# Altium schematic sheet RoBeast_uC.SchDoc(RoBeast_uC)
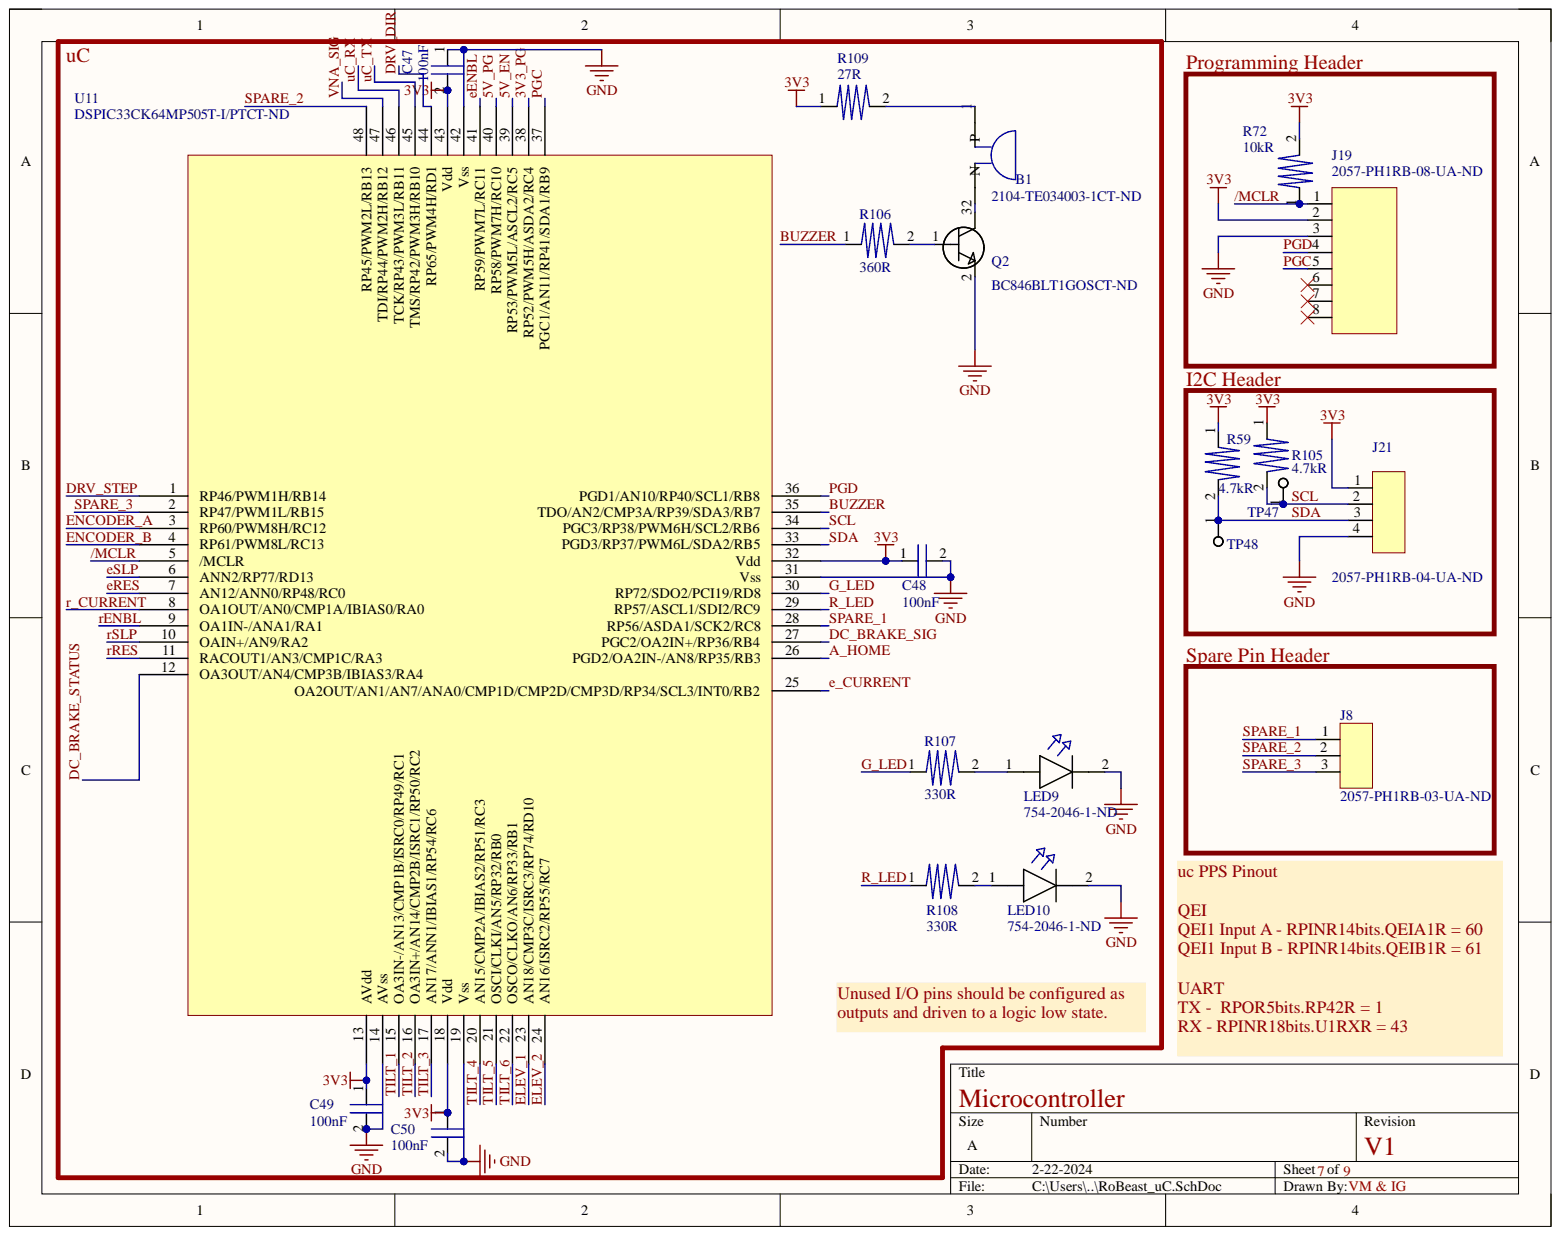
[diagram p0: RoBeast_uC.SchDoc]

## Parts
B1  Supplier Part Number 1: 2104-TE034003-1CT-ND  [TE034003-1]  (hidden comment: Buzzer)
C47  100nF  [0805]
C48  100nF  [0805]
C49  100nF  [0805]
C50  100nF  [0805]
J8  Supplier Part Number: 2057-PH1RB-03-UA-ND  [PH1RB-03-UA]  (hidden comment: 3 Pin Connector)
J19  Supplier Part Number 1: 2057-PH1RB-08-UA-ND  [PH1RB-08-UA]  (hidden comment: 8 Pin Connector)
J21  Supplier Part Number: 2057-PH1RB-04-UA-ND  [PH1RB-04-UA]  (hidden comment: 4 Pin Connector)
LED9  Supplier Part Number 1: 754-2046-1-ND  [0805_LED]  (hidden comment: Blue LED)
LED10  Supplier Part Number 1: 754-2046-1-ND  [0805_LED]  (hidden comment: Blue LED)
Q2  Supplier Part Number 1: BC846BLT1GOSCT-ND  [BC846BLT1G]  (hidden comment: NPN BJT)
R59  4.7kR  [0805]
R72  10kR  [0805]
R105  4.7kR  [0805]
R106  360R  [0805]
R107  330R  [0805]
R108  330R  [0805]
R109  27R  [0805]
TP47  Test Point  [RCWCTE]  (value not drawn)
TP48  Test Point  [RCWCTE]  (value not drawn)
U11  uC  Supplier Part Number: DSPIC33CK64MP505T-I/PTCT-ND  [DSPIC33CK64MP505T-I/PT]

## Nets
3V3: C47.2 C48.1 C49.1 C50.1 J19.2 J21.1 R59.1 R72.2 R105.1 R109.1 U11.13(AVdd) U11.18(Vdd) U11.32(Vdd) U11.43(Vdd)
GND: C47.1 C48.2 C49.2 C50.2 J19.3 J21.4 LED9.2 LED10.2 Q2.2 U11.14(AVss) U11.19(Vss) U11.31(Vss) U11.42(Vss)
3V3_PG: U11.38(RP52/PWM5H/ASDA2/RC4)
5V_EN: U11.39(RP53/PWM5L/ASCL2/RC5)
5V_PG: U11.40(RP58/PWM7H/RC10)
/MCLR: J19.1 R72.1 U11.5(/MCLR)
A_HOME: U11.26(PGD2/OA2IN-/AN8/RP35/RB3)
BUZZER: R106.1 U11.35(TDO/AN2/CMP3A/RP39/SDA3/RB7)
DC_BRAKE_SIG: U11.27(PGC2/OA2IN+/RP36/RB4)
DC_BRAKE_STATUS: U11.12(OA3OUT/AN4/CMP3B/IBIAS3/RA4)
DRV_DIR: U11.44(RP65/PWM4H/RD1)
DRV_STEP: U11.1(RP46/PWM1H/RB14)
e_CURRENT: U11.25(OA2OUT/AN1/AN7/ANA0/CMP1D/CMP2D/CMP3D/RP34/SCL3/INT0/RB2)
eENBL: U11.41(RP59/PWM7L/RC11)
ELEV_1: U11.23(AN18/CMP3C/ISRC3/RP74/RD10)
ELEV_2: U11.24(AN16/ISRC2/RP55/RC7)
ENCODER_A: U11.3(RP60/PWM8H/RC12)
ENCODER_B: U11.4(RP61/PWM8L/RC13)
eRES: U11.7(AN12/ANN0/RP48/RC0)
eSLP: U11.6(ANN2/RP77/RD13)
G_LED: R107.1 U11.30(RP72/SDO2/PCI19/RD8)
PGC: J19.5 U11.37(PGC1/AN11/RP41/SDA1/RB9)
PGD: J19.4 U11.36(PGD1/AN10/RP40/SCL1/RB8)
r_CURRENT: U11.8(OA1OUT/AN0/CMP1A/IBIAS0/RA0)
R_LED: R108.1 U11.29(RP57/ASCL1/SDI2/RC9)
rENBL: U11.9(OA1IN-/ANA1/RA1)
rRES: U11.11(RACOUT1/AN3/CMP1C/RA3)
rSLP: U11.10(OAIN+/AN9/RA2)
SCL: J21.2 R105.2 TP47.1 U11.34(PGC3/RP38/PWM6H/SCL2/RB6)
SDA: J21.3 R59.2 TP48.1 U11.33(PGD3/RP37/PWM6L/SDA2/RB5)
SPARE_1: J8.1 U11.28(RP56/ASDA1/SCK2/RC8)
SPARE_2: J8.2 U11.48(RP45/PWM2L/RB13)
SPARE_3: J8.3 U11.2(RP47/PWM1L/RB15)
TILT_1: U11.15(OA3IN-/AN13/CMP1B/ISRC0/RP49/RC1)
TILT_2: U11.16(OA3IN+/AN14/CMP2B/ISRC1/RP50/RC2)
TILT_3: U11.17(AN17/ANN1/IBIAS1/RP54/RC6)
TILT_4: U11.20(AN15/CMP2A/IBIAS2/RP51/RC3)
TILT_5: U11.21(OSCI/CLKI/AN5/RP32/RB0)
TILT_6: U11.22(OSCO/CLKO/AN6/RP33/RB1)
uC_RX: U11.46(TCK/RP43/PWM3L/RB11)
uC_TX: U11.45(TMS/RP42/PWM3H/RB10)
VNA_SIG: U11.47(TDI/RP44/PWM2H/RB12)
NetB1_1: B1.1 R109.2
NetB1_2: B1.2 Q2.3
NetLED9_1: LED9.1 R107.2
NetLED10_1: LED10.1 R108.2
NetQ2_1: Q2.1 R106.2

Not connected: J19 6 7 8

## Library data of the parts
B1 = TE034003-1: Electro-mechanical Transducer; Manufacturer: DB Unlimited; Category: Audio Products; Component Kind: Standard; Manufacturer Part Number: TE034003-1; Pricing 1: 1=2.3, 10=1.911, 25=1.7652, 50=1.659, 100=1.5568, 250=1.44984, 500=1.33496, 1000=1.29987 (USD); RoHS: No; Stock 1: 5468; Supplier 1: Digikey; Library Name: RoBeast_Schematic_Library.SchLib
C47-C50 = C0805C104J5RAC7800: Ceramic Capacitor, Multilayer, Ceramic, 50V, 5% +Tol, 5% -Tol, X7R, 15% TC, 0.1uF, Surface Mount, 0805; Manufacturer: KEMET; Manufacturer Part Number: C0805C104J5RACTU; Multilayer: Yes; Capacitance: 100nF; Capacitor Type: Ceramic; Category: Ceramic Capacitors; Component Kind: Standard; ComponentLink1Description: http://capacitoredge.kemet.com:80/capedge2/intellispec.jsp?pn=C0805C104J5RACTU&displayPN=C0805C104J5RACTU&src-supplier=IHS+Markit; ComponentLink1URL: http://capacitoredge.kemet.com:80/capedge2/intellispec.jsp?pn=C0805C104J5RACTU&displayPN=C0805C104J5RACTU&src-supplier=IHS+Markit; ComponentLink2Description: https://datasheet.ciiva.com/7842/1732721-7842179.pdf?src-supplier=Element14; ComponentLink2URL: https://datasheet.ciiva.com/7842/1732721-7842179.pdf?src-supplier=Element14; ComponentLink3Description: https://datasheet.ciiva.com/28682/1851736-28682223.pdf?src-supplier=Element14; ComponentLink3URL: https://datasheet.ciiva.com/28682/1851736-28682223.pdf?src-supplier=Element14; ComponentLink4Description: https://datasheet.ciiva.com/7258/1828800-7258413.pdf?src-supplier=Newark; ComponentLink4URL: https://datasheet.ciiva.com/7258/1828800-7258413.pdf?src-supplier=Newark; ComponentLink5Description: https://datasheet.ciiva.com/14589/70095383-14589015.pdf?src-supplier=Allied+Electronics; ComponentLink5URL: https://datasheet.ciiva.com/14589/70095383-14589015.pdf?src-supplier=Allied+Electronics; ComponentLink6Description: https://datasheet.ciiva.com/5417/kem-c1002-x7r-smd-5417352.pdf?src-supplier=Mouser; ComponentLink6URL: https://datasheet.ciiva.com/5417/kem-c1002-x7r-smd-5417352.pdf?src-supplier=Mouser; ComponentLink7Description: https://datasheet.ciiva.com/39122/docfinderpartnumberc0402c102k5ractu-39122924.pdf?src-supplier=Onlinecomponents.com; ComponentLink7URL: https://datasheet.ciiva.com/39122/docfinderpartnumberc0402c102k5ractu-39122924.pdf?src-supplier=Onlinecomponents.com; Dielectric Material: Ceramic; JESD-609 Code: e3; Max Operating Temperature: 125°C; Min Operating Temperature: -55°C; Mount: Surface Mount; Negative Tolerance: 5%; Number of Pins: 2; Package Shape: Rectangular; Packaging: Tape and Reel; Positive Tolerance: 5%; Pricing 1: 1=0.18, 10=0.122, 50=0.0732, 100=0.0576, 500=0.04252, 1000=0.03519 (USD); Rated DC Voltage (URdc): 50V; Reach SVHC Compliant: Yes; RoHS: Yes; RoHS Compliant: Yes; Size Code: 0805; Stock 1: 605061; Supplier 1: Digikey; Supplier Part Number 1: 399-C0805C104J5RAC7800CT-ND; Temperature Characteristics Code: X7R; Terminal Finish: Nickel; Termination: Wraparound; Library Name: RoBeast_Schematic_Library.SchLib
J8 = PH1RB-03-UA: Conn Unshrouded Header HDR 3 POS 2.54mm Solder RA Side Entry Thru-Hole; Manufacturer: Adam Equipment; Category: Connectors; Component Kind: Standard; ComponentLink1Description: http://www.adam-tech.com/downloader.php?p=PH1RB-XX-UA.pdf&src-supplier=Digikey; ComponentLink1URL: http://www.adam-tech.com/downloader.php?p=PH1RB-XX-UA.pdf&src-supplier=Digikey; Manufacturer Part Number: PH1RB-03-UA; Pricing: 1=0.12, 10=0.097, 100=0.0718, 500=0.05938, 1000=0.05196, 5000=0.04454, 10000=0.0433 (USD); RoHS: No; Stock: 27919; Supplier: Digikey; Library Name: RoBeast_Schematic_Library.SchLib
J19 = PH1RB-08-UA: Conn Unshrouded Header HDR 8 POS 2.54mm Solder RA Thru-Hole; Manufacturer: Adam Equipment; Category: Connectors; Component Kind: Standard; Manufacturer Part Number: PH1RB-08-UA; Pricing 1: 1=0.23, 10=0.196, 100=0.1505, 500=0.12738, 1000=0.10423, 5000=0.09265, 10000=0.08396 (USD); RoHS: No; Stock 1: 10117; Supplier 1: Digikey; Library Name: RoBeast_Schematic_Library.SchLib
J21 = PH1RB-04-UA: Conn Unshrouded Header HDR 4 POS 2.54mm Solder RA Thru-Hole; Manufacturer: Adam Equipment; Category: Connectors; Component Kind: Standard; ComponentLink1Description: http://www.adam-tech.com/downloader.php?p=PH1RB-XX-UA.pdf&src-supplier=Digikey; ComponentLink1URL: http://www.adam-tech.com/downloader.php?p=PH1RB-XX-UA.pdf&src-supplier=Digikey; Manufacturer Part Number: PH1RB-04-UA; Pricing: 1=0.12, 10=0.094, 100=0.0697, 500=0.0577, 1000=0.05048, 5000=0.04327, 10000=0.04207 (USD); RoHS: No; Stock: 13315; Supplier: Digikey; Library Name: RoBeast_Schematic_Library.SchLib
LED9 LED10 = APTD2012LQBC/D: Single Color LED; Manufacturer: Kingbright; Category: LEDs; Component Kind: Standard; ComponentLink1Description: https://datasheet.ciiva.com:443/pdfs/VipMasterIC/IC/KBEC/KBEC-S-A0008946974/KBEC-S-A0008947044-1.pdf?src-supplier=IHS+Markit; ComponentLink1URL: https://datasheet.ciiva.com:443/pdfs/VipMasterIC/IC/KBEC/KBEC-S-A0008946974/KBEC-S-A0008947044-1.pdf?src-supplier=IHS+Markit; ComponentLink2Description: http://www.kingbrightusa.com/images/catalog/SPEC/APTD2012LQBC-D.pdf?src-supplier=Digikey; ComponentLink2URL: http://www.kingbrightusa.com/images/catalog/SPEC/APTD2012LQBC-D.pdf?src-supplier=Digikey; Manufacturer Part Number: APTD2012LQBC/D; Pricing 1: 1=0.54, 10=0.358, 100=0.2367, 1000=0.18275 (USD); RoHS: Yes; RoHS Compliant: Yes; Stock 1: 20866; Supplier 1: Digikey; Library Name: RoBeast_Schematic_Library.SchLib
Q2 = BC846BLT1G: Small Signal Bipolar Transistor, 0.1A I(C), 65V V(BR)CEO, 1-Element, NPN, Silicon, TO-236; Manufacturer: ON Semiconductor; DC Current Gain-Min (hFE): 200; Max Collector Current: 100mA; Number of Elements: 1; Package Body Material: Plastic; Power Dissipation-Max (Abs): 225mW; Qualification Status: Not Qualified; Time @ Peak Reflow Temperature-Max (s): 40s; Transistor Application: SWITCHING; Transition Frequency-Nom (fT): 100MHz; Category: BJTs; Collector-emitter Voltage-Max: 65V; Component Kind: Standard; ComponentLink1Description: https://datasheet.ciiva.com:443/pdfs/VipMasterIC/IC/ONSM/ONSM-S-A0009451914/ONSM-S-A0009451914-1.pdf?src-supplier=IHS+Markit; ComponentLink1URL: https://datasheet.ciiva.com:443/pdfs/VipMasterIC/IC/ONSM/ONSM-S-A0009451914/ONSM-S-A0009451914-1.pdf?src-supplier=IHS+Markit; ComponentLink2Description: https://datasheet.ciiva.com/15138/getdatasheetpartid-38790-15138644.pdf?src-supplier=Verical; ComponentLink2URL: https://datasheet.ciiva.com/15138/getdatasheetpartid-38790-15138644.pdf?src-supplier=Verical; ComponentLink3Description: https://datasheet.ciiva.com/27056/2010421-27056146.pdf?src-supplier=Jameco; ComponentLink3URL: https://datasheet.ciiva.com/27056/2010421-27056146.pdf?src-supplier=Jameco; ComponentLink4Description: https://datasheet.ciiva.com/7972/bc846alt1-d-7972189.pdf?src-supplier=Element14; ComponentLink4URL: https://datasheet.ciiva.com/7972/bc846alt1-d-7972189.pdf?src-supplier=Element14; JEDEC-95 Code: TO-236; JESD-30 Code: R-PDSO-G3; JESD-609 Code: e3; Manufacturer Part Number: BC846BLT1G; Max Operating Temperature: 150°C; Moisture Sensitivity Level: 1; Number of Pins: 3; Package Shape: Rectangular; Package Style: SMALL OUTLINEMeter; Peak Reflow Temperature (Cel): 260°C; Polarity/Channel Type: NPN; Pricing 1: 1=0.14, 10=0.097, 100=0.0522, 500=0.04102, 1000=0.02848 (USD); Reach SVHC Compliant: Yes; RoHS: Yes; RoHS Compliant: Yes; Stock 1: 1618453; Supplier 1: Digikey; Surface Mount: Yes; Terminal Finish: Tin; Terminal Form: Gull Wing; Terminal Position: DUAL; Transistor Element Material: SILICON; Library Name: RoBeast_Schematic_Library.SchLib
R59 R105 = RCC08054K70FKEA: Fixed Resistor, Metal Glaze/thick Film, 0.25W, 4700ohm, 200V, 1% +/-Tol, 100ppm/Cel, Surface Mount, 0805; Manufacturer: Vishay Dale; Category: Chip SMD Resistors; Component Kind: Standard; Manufacturer Part Number: RCC08054K70FKEA; Pricing 1: 1=0.15, 10=0.069, 50=0.0454, 100=0.0385, 500=0.0271, 1000=0.0236 (USD); RoHS: Yes; Stock 1: 96612; Supplier 1: Digikey; Supplier Part Number 1: 541-RCC08054K70FKEACT-ND; Library Name: RoBeast_Schematic_Library.SchLib
R72 = RMCF0805FT10K0: Fixed Resistor, Metal Glaze/thick Film, 0.125W, 10000ohm, 150V, 1% +/-Tol, 100ppm/Cel, Surface Mount, 0805; Manufacturer: Stackpole Electronics; Package Height: 0.5mm; Package Length: 2mm; Package Width: 1.25mm; Rated Power Dissipation (P): 125mW; Rated Temperature: 70°C; Reference Standard: AEC-Q200; Temperature Coefficient: 100ppm/°C; Category: Chip SMD Resistors; Component Kind: Standard; ComponentLink1Description: https://datasheet.ciiva.com:443/pdfs/VipMasterIC/IC/SEIE/SEIE-S-A0009541969/SEIE-S-A0009541969-1.pdf?src-supplier=IHS+Markit; ComponentLink1URL: https://datasheet.ciiva.com:443/pdfs/VipMasterIC/IC/SEIE/SEIE-S-A0009541969/SEIE-S-A0009541969-1.pdf?src-supplier=IHS+Markit; ComponentLink2Description: https://datasheet.ciiva.com/15193/getdatasheetpartid-362046-15193525.pdf?src-supplier=Verical; ComponentLink2URL: https://datasheet.ciiva.com/15193/getdatasheetpartid-362046-15193525.pdf?src-supplier=Verical; Construction: Rectangular; JESD-609 Code: e3; Manufacturer Part Number: RMCF0805FT10K0; Max Operating Temperature: 155°C; Min Operating Temperature: -55°C; Mount: Surface Mount; Number of Pins: 2; Package Shape: Rectangular; Package Style: SMTMeter; Packaging: Tape and Reel; Pricing 1: 1=0.1, 10=0.02, 50=0.0124, 100=0.0103, 500=0.007, 1000=0.00601 (USD); Reach SVHC Compliant: Yes; Resistance: 10kR; Resistor Type: FIXED RESISTOR; RoHS: Yes; RoHS Compliant: Yes; Size Code: 0805; Stock 1: 3602197; Supplier 1: Digikey; Supplier Part Number 1: RMCF0805FT10K0CT-ND; Technology: METAL GLAZE/THICK FILM; Terminal Finish: Nickel; Termination: Wraparound; Tolerance: 1%; Working Voltage: 150V; Library Name: RoBeast_Schematic_Library.SchLib
R106 = RC0805FR-07360RL: Fixed Resistor, Metal Glaze/thick Film, 0.125W, 360ohm, 150V, 1% +/-Tol, 100ppm/Cel, Surface Mount, 0805; Manufacturer: Yageo; Additional Feature: LASER TRIMMABLE; Package Height: 0.5mm; Package Length: 2mm; Package Width: 1.25mm; Rated Power Dissipation (P): 125mW; Rated Temperature: 70°C; Temperature Coefficient: 100ppm/°C; Category: Chip SMD Resistors; Component Kind: Standard; ComponentLink1Description: https://datasheet.ciiva.com:443/pdfs/VipMasterIC/IC/YAGO/YAGO-S-A0008145938/YAGO-S-A0008145938-1.pdf?src-supplier=IHS+Markit; ComponentLink1URL: https://datasheet.ciiva.com:443/pdfs/VipMasterIC/IC/YAGO/YAGO-S-A0008145938/YAGO-S-A0008145938-1.pdf?src-supplier=IHS+Markit; ComponentLink2Description: https://datasheet.ciiva.com/11808/0900766b8106beab-11808261.pdf?src-supplier=Rs; ComponentLink2URL: https://datasheet.ciiva.com/11808/0900766b8106beab-11808261.pdf?src-supplier=Rs; ComponentLink3Description: https://datasheet.ciiva.com/17423/rc0805-17423149.pdf?src-supplier=Future+Electronics; ComponentLink3URL: https://datasheet.ciiva.com/17423/rc0805-17423149.pdf?src-supplier=Future+Electronics; ComponentLink4Description: https://datasheet.ciiva.com/12667/rc0805-12667401.pdf?src-supplier=Mouser; ComponentLink4URL: https://datasheet.ciiva.com/12667/rc0805-12667401.pdf?src-supplier=Mouser; Construction: Chip; JESD-609 Code: e3; Manufacturer Part Number: RC0805FR-07360RL; Max Operating Temperature: 155°C; Min Operating Temperature: -55°C; Mount: Surface Mount; Number of Pins: 2; Package Shape: Rectangular; Package Style: SMTMeter; Packaging: Tape and Reel; Pricing 1: 1=0.1, 10=0.026, 50=0.0162, 100=0.0136, 500=0.00938, 1000=0.0081 (USD); Reach SVHC Compliant: Yes; Resistance: 360R; Resistor Type: FIXED RESISTOR; RoHS: Yes; RoHS Compliant: Yes; Size Code: 0805; Stock 1: 62488; Supplier 1: Digikey; Supplier Part Number 1: 311-360CRCT-ND; Technology: METAL GLAZE/THICK FILM; Terminal Finish: Nickel; Termination: Wraparound; Tolerance: 1%; Working Voltage: 150V; Library Name: RoBeast_Schematic_Library.SchLib
R107 R108 = RC0805FR-13330RL: Fixed Resistor, Metal Glaze/thick Film, 0.125W, 330ohm, 150V, 1% +/-Tol, 100ppm/Cel, Surface Mount, 0805; Manufacturer: Yageo; Additional Feature: LASER TRIMMABLE; Package Height: 0.5mm; Package Length: 2mm; Package Width: 1.25mm; Rated Power Dissipation (P): 125mW; Rated Temperature: 70°C; Temperature Coefficient: 100ppm/°C; Category: Chip SMD Resistors; Component Kind: Standard; ComponentLink1Description: https://datasheet.ciiva.com:443/pdfs/VipMasterIC/IC/YAGO/YAGO-S-A0008145938/YAGO-S-A0008145938-1.pdf?src-supplier=IHS+Markit; ComponentLink1URL: https://datasheet.ciiva.com:443/pdfs/VipMasterIC/IC/YAGO/YAGO-S-A0008145938/YAGO-S-A0008145938-1.pdf?src-supplier=IHS+Markit; ComponentLink2Description: https://datasheet.ciiva.com/12575/rc0805-257173-12575525.pdf?src-supplier=Mouser; ComponentLink2URL: https://datasheet.ciiva.com/12575/rc0805-257173-12575525.pdf?src-supplier=Mouser; Construction: Chip; JESD-609 Code: e3; Manufacturer Part Number: RC0805FR-13330RL; Max Operating Temperature: 155°C; Min Operating Temperature: -55°C; Mount: Surface Mount; Number of Pins: 2; Package Shape: Rectangular; Package Style: SMTMeter; Packaging: Tape and Reel; Pricing 1: 1=0.1, 10=0.025, 50=0.016, 100=0.0133, 500=0.00912, 1000=0.00787, 5000=0.00577, 10000=0.00511 (USD); Reach SVHC Compliant: Yes; Resistance: 330R; Resistor Type: FIXED RESISTOR; RoHS: Yes; RoHS Compliant: Yes; Size Code: 0805; Stock 1: 36292; Supplier 1: Digikey; Supplier Part Number 1: 13-RC0805FR-13330RLCT-ND; Technology: METAL GLAZE/THICK FILM; Terminal Finish: Nickel; Termination: Wraparound; Tolerance: 1%; Working Voltage: 150V; Library Name: RoBeast_Schematic_Library.SchLib
R109 = SG73P2ATTD27R0F: Fixed Resistor, Metal Glaze/thick Film, 0.5W, 27ohm, 400V, 1% +/-Tol, 200ppm/Cel, Surface Mount, 0805; Manufacturer: KOA Speer; Package Height: 0.5mm; Package Length: 2mm; Package Width: 1.25mm; Rated Power Dissipation (P): 250mW; Rated Temperature: 70°C; Reference Standard: AEC-Q200; Temperature Coefficient: 200ppm/°C; Category: Chip SMD Resistors; Component Kind: Standard; ComponentLink1Description: https://datasheet.ciiva.com:443/pdfs/VipMasterIC/IC/KOAS/KOAS-S-A0009586268/KOAS-S-A0009586268-1.pdf?src-supplier=IHS+Markit; ComponentLink1URL: https://datasheet.ciiva.com:443/pdfs/VipMasterIC/IC/KOAS/KOAS-S-A0009586268/KOAS-S-A0009586268-1.pdf?src-supplier=IHS+Markit; ComponentLink2Description: http://www.koaspeer.com/catimages/Products/SG73/SG73.pdf?src-supplier=Mouser; ComponentLink2URL: http://www.koaspeer.com/catimages/Products/SG73/SG73.pdf?src-supplier=Mouser; Construction: Rectangular; JESD-609 Code: e3; Manufacturer Part Number: SG73P2ATTD27R0F; Max Operating Temperature: 155°C; Min Operating Temperature: -55°C; Mount: Surface Mount; Number of Pins: 2; Package Style: SMTMeter; Packaging: Tape and Reel; Pricing 1: 1=0.18, 10=0.09, 50=0.0602, 100=0.0514, 500=0.03686, 1000=0.03245 (USD); Reach SVHC Compliant: Yes; Resistance: 27R; Resistor Type: FIXED RESISTOR; RoHS: Yes; RoHS Compliant: Yes; Size Code: 0805; Stock 1: 9351; Supplier 1: Digikey; Supplier Part Number 1: 2019-SG73P2ATTD27R0FCT-ND; Technology: METAL GLAZE/THICK FILM; Terminal Finish: Nickel; Termination: Wraparound; Tolerance: 1%; Working Voltage: 400V; Library Name: RoBeast_Schematic_Library.SchLib
TP47 TP48 = RCWCTE: Testing/Accessories; Manufacturer: KOA Speer; Package Height: 2mm; Package Length: 3.2mm; Package Width: 1.6mm; Rated Temperature: 70°C; Reference Standard: AEC-Q200; Resistance: 0R; Category: Chip SMD Resistors; Component Kind: Standard; ComponentLink1Description: https://datasheet.ciiva.com:443/pdfs/VipMasterIC/IC/KOAS/KOASS03065/KOASS03065-1.pdf?src-supplier=IHS+Markit; ComponentLink1URL: https://datasheet.ciiva.com:443/pdfs/VipMasterIC/IC/KOAS/KOASS03065/KOASS03065-1.pdf?src-supplier=IHS+Markit; ComponentLink2Description: https://datasheet.ciiva.com/34302/1876186-34302940.pdf?src-supplier=Jameco; ComponentLink2URL: https://datasheet.ciiva.com/34302/1876186-34302940.pdf?src-supplier=Jameco; ComponentLink3Description: https://datasheet.ciiva.com/5556/rc-5556236.pdf?src-supplier=Mouser; ComponentLink3URL: https://datasheet.ciiva.com/5556/rc-5556236.pdf?src-supplier=Mouser; ComponentLink4Description: https://datasheet.ciiva.com/26821/getdatasheetpartid-110197-26821157.pdf?src-supplier=Verical; ComponentLink4URL: https://datasheet.ciiva.com/26821/getdatasheetpartid-110197-26821157.pdf?src-supplier=Verical; Construction: Chip; JESD-609 Code: e2; Manufacturer Part Number: RCWCTE; Max Operating Temperature: 125°C; Min Operating Temperature: -55°C; Mount: Surface Mount; Number of Pins: 2; Package Shape: Rectangular; Package Style: SMTMeter; Packaging: Tape and Reel; Pricing 1: 1=0.16, 10=0.137, 25=0.1212, 50=0.1132, 100=0.105, 250=0.09292, 500=0.08888, 1000=0.07272 (USD); Reach SVHC Compliant: Yes; Resistor Type: FIXED RESISTOR; RoHS: Yes; RoHS Compliant: Yes; Size Code: 1206; Stock 1: 132357; Supplier 1: Digikey; Supplier Part Number 1: 2019-RCWCTECT-ND; Terminal Finish: Copper; Termination: Wraparound; Library Name: RoBeast_Schematic_Library.SchLib
U11 = DSPIC33CK64MP505T-I/PT: 16-Bit Size, 0-Ext Bit, 64MHz, CMOS, PQFP48; Manufacturer: Microchip; Category: Microcontrollers; Component Kind: Standard; ComponentLink1Description: https://datasheet.ciiva.com:443/pdfs/VipMasterIC/IC/MCHP/MCHP-S-A0007771634/MCHP-S-A0007771634-1.pdf?src-supplier=IHS+Markit; ComponentLink1URL: https://datasheet.ciiva.com:443/pdfs/VipMasterIC/IC/MCHP/MCHP-S-A0007771634/MCHP-S-A0007771634-1.pdf?src-supplier=IHS+Markit; ComponentLink2Description: http://www.microchip.com/mymicrochip/filehandler.aspx?ddocname=en606233&src-supplier=Digikey; ComponentLink2URL: http://www.microchip.com/mymicrochip/filehandler.aspx?ddocname=en606233&src-supplier=Digikey; Manufacturer Part Number: DSPIC33CK64MP505T-I/PT; Pricing: 1=3.75, 25=3.44, 100=3.12 (USD); RoHS: No; Stock: 1600; Supplier: Digikey; Library Name: RoBeast_Schematic_Library.SchLib
